# Altium schematic sheet Э3("All Documents",Physical)
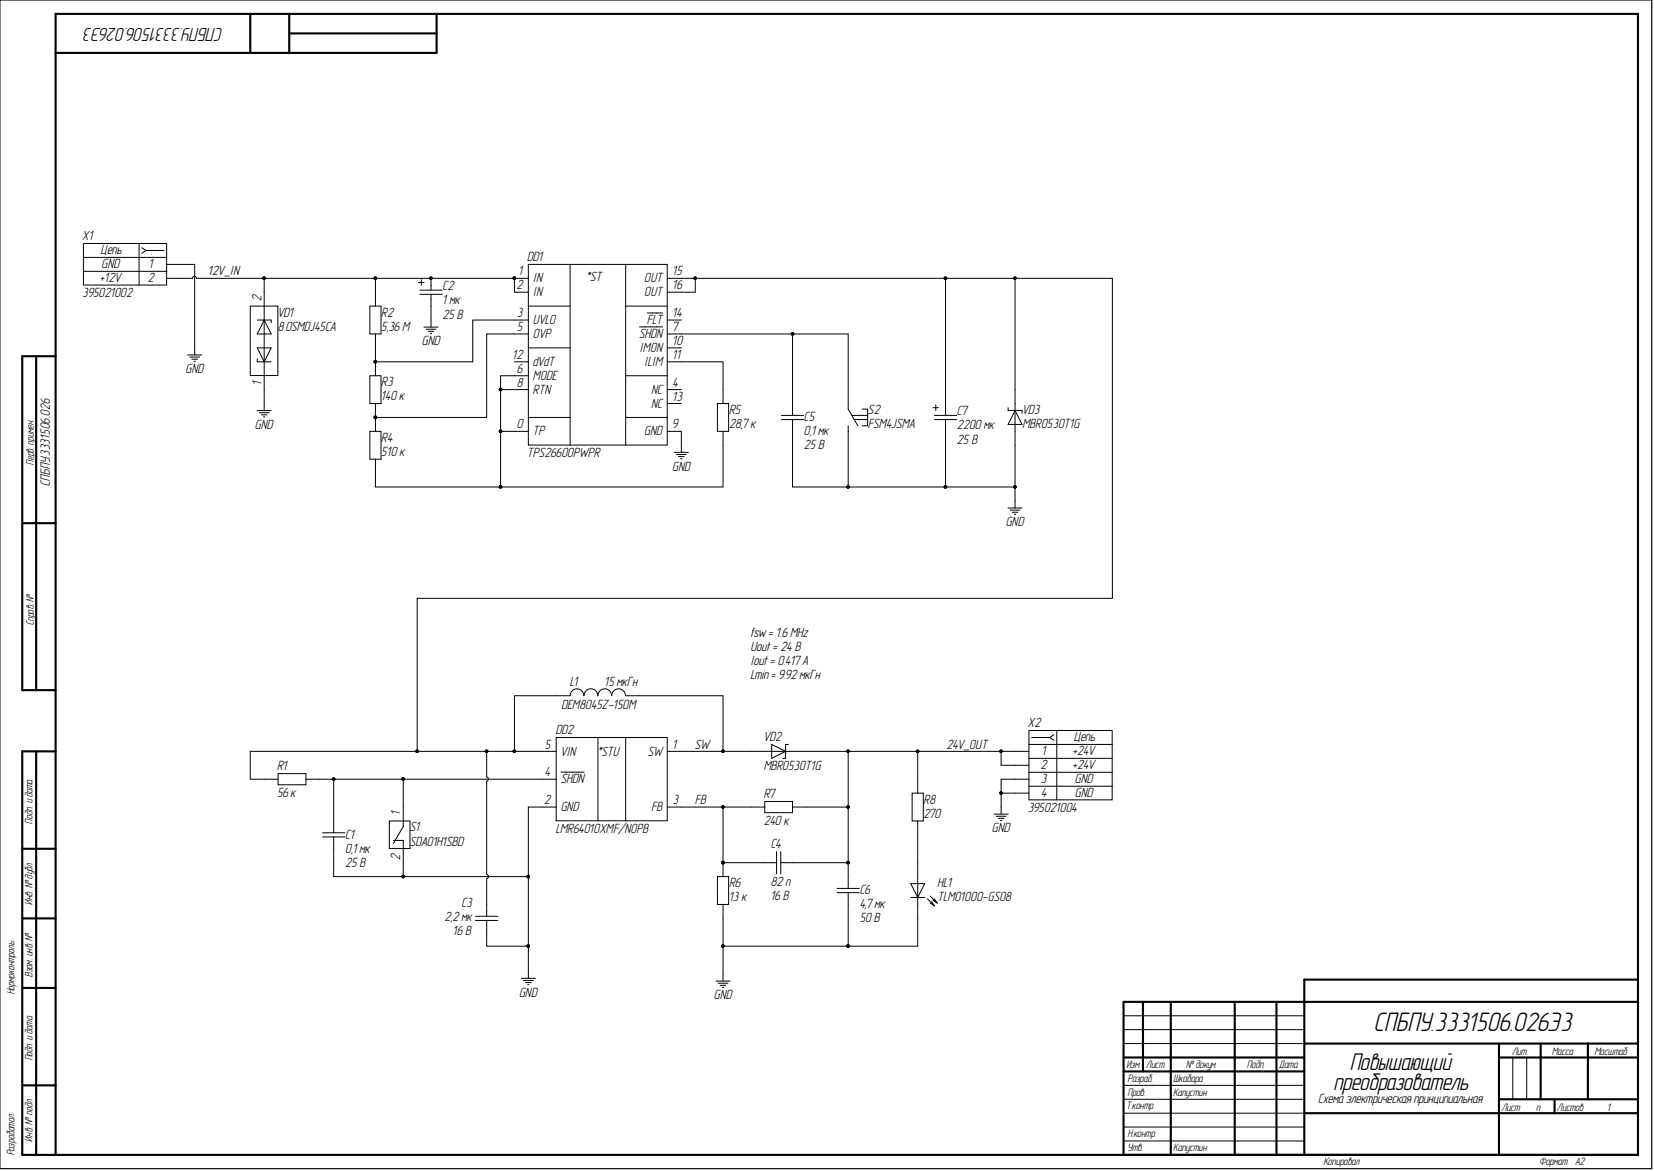
[diagram p0: Э3("All Documents",Physical)]

## Parts
C1  0,1 мк  Номинальное напряжение: 25 В  [C0402]
C2  1 мк  Номинальное напряжение: 25 В  [C1206POLAR]
C3  2,2 мк  Номинальное напряжение: 16 В  [C0603]
C4  82 п  Номинальное напряжение: 16 В  [C0603]
C5  0,1 мк  Номинальное напряжение: 25 В  [C0402]
C6  4,7 мк  Номинальное напряжение: 50 В  [C0805]
C7  2200 мк  Номинальное напряжение: 25 В  [CAPAE-1600X1600X2100]
DD1  TPS26600PWPR  [HTSSOP-16]
DD2  LMR64010XMF/NOPB  [SOT23-5]
HL1  TLMO1000-GS08  [HL0603]
L1  DEM8045Z-150M  Номинал: 15 мкГн  [DEM-2-800X800X450]
R1  56 к  [R0603]
R2  5,36 M  [R0603]
R3  140 к  [R0603]
R4  510 к  [R0603]
R5  28,7 к  [R0603]
R6  13 к  [R0603]
R7  240 к  [R0603]
R8  270  [R0603]
S1  SDA01H1SBD  [DIP-SWITCH-01-452X930X545]
S2  FSM4JSMA  [FSM4JSMA]
VD1  8.0SMDJ45CA  [DO-214AB]
VD2  MBR0530T1G  [SOD123]
VD3  MBR0530T1G  [SOD123]
X1  395021002  Contact_2: +12V  Contact_1: GND  [395021002]
X2  395021004  Contact_4: GND  Contact_2: +24V  [395021004]

## Nets
GND: C1.2 C2.2 C3.2 C5.2 C6.2 C7.2 DD1.9(GND) DD2.2(GND) HL1.1 R6.1 S1.2 S2.2 VD1.1 VD3.2 X1.1 X2.3 X2.4
12V_IN: C2.1 DD1.1(IN) DD1.2(IN) R2.2 VD1.2 X1.2
24V_OUT: C4.1 C6.1 R7.2 R8.2 VD2.1 X2.1 X2.2
FB: C4.2 DD2.3(FB) R6.2 R7.1
SW: DD2.1(SW) L1.2 VD2.2
NetC1_1: C1.1 DD2.4(SHDN) R1.1 S1.1
NetC3_1: C3.1 C7.1 DD1.15(OUT) DD1.16(OUT) DD2.5(VIN) L1.1 R1.2 VD3.1
NetC5_1: C5.1 DD1.7(SHDN) S2.1
NetDD1_0: DD1.0(TP) DD1.6(MODE) DD1.8(RTN) R4.1 R5.1
NetDD1_3: DD1.3(UVLO) R2.1 R3.2
NetDD1_4: DD1.4(NC)
NetDD1_5: DD1.5(OVP) R3.1 R4.2
NetDD1_10: DD1.10(IMON)
NetDD1_11: DD1.11(ILIM) R5.2
NetDD1_12: DD1.12(dVdT)
NetDD1_13: DD1.13(NC)
NetDD1_14: DD1.14(FLT)
NetHL1_2: HL1.2 R8.1

## Library data of the parts
C1 C5 = c0021: =Описание; Фамилия: Паньков; ТКЕ: X5R; Рабочие температуры: от –55 °С до +85 °С; Производитель: YAGEO Corp.; Отклонение: ±10 %; Описание: Конденсатор чип 0402 0,1 мкФ 25 В ±10 %; Наименование: CC0402KRX5R8BB104; Корпус: 0402; Database Table Name: Конденсаторы$; Номинал: 0,1 мк; Напряжение: 25 В; ID: c0021; HelpURL: https://www.yageo.com/upload/media/product/productsearch/datasheet/mlcc/UPY-GPHC_X5R_4V-to-50V_26.pdf; Description: Конденсатор чип 0402 0,1 мкФ 25 В ±10 %; Component Kind: Standard; Library Name: Database_Students.DbLib
C2 = c0107: =Описание; Фамилия: Ларионов; ТКЕ: X7R; Рабочие температуры: от –55 °С до +125 °С; Производитель: Vishay Intertechnology, Inc.; Отклонение: ±10 %; Описание: Конденсатор чип 1206 1 мкФ 25 В ±10 % полярный; Наименование: 293D105X9025A2TE3; Корпус: 1206; Database Table Name: Конденсаторы$; Номинал: 1 мк; Напряжение: 25 В; ID: c0107; HelpURL: https://www.vishay.com/capacitors/list/product-40002/; Description: Конденсатор чип 1206 1 мкФ 25 В ±10 % полярный; Component Kind: Standard; Library Name: Database_Students.DbLib
C3 = c0061: =Описание; Фамилия: Паньков; ТКЕ: X5R; Рабочие температуры: от –55 °С до +85 °С; Производитель: YAGEO Corp.; Отклонение: ±10 %; Описание: Конденсатор чип 0603 2,2 мкФ 16 В ±10 %; Наименование: CC0603KRX5R7BB225; Корпус: 0603; Database Table Name: Конденсаторы$; Номинал: 2,2 мк; Напряжение: 16 В; ID: c0061; HelpURL: https://www.yageo.com/upload/media/product/productsearch/datasheet/mlcc/UPY-GPHC_X5R_4V-to-50V_26.pdf; Description: Конденсатор чип 0603 2,2 мкФ 16 В ±10 %; Component Kind: Standard; Library Name: Database_Students.DbLib
C4 = c0011: =Описание; Фамилия: Ларионов; ТКЕ: C0G; Рабочие температуры: от –55 °С до +125 °С; Производитель: YAGEO Corp.; Отклонение: ±5 %; Описание: Конденсатор чип 0603 82 пФ 16 В ±5 %; Наименование: CC0603JRNPO7BN820; Корпус: 0603; Database Table Name: Конденсаторы$; Номинал: 82 п; Напряжение: 16 В; ID: c0011; HelpURL: https://www.yageo.com/upload/media/product/productsearch/datasheet/mlcc/UPY-GP_NP0_16V-to-50V_18.pdf; Description: Конденсатор чип 0603 82 пФ 16 В ±5 %; Component Kind: Standard; Library Name: Database_Students.DbLib
C6 = c0073: =Описание; Фамилия: Паньков; ТКЕ: X5R; Рабочие температуры: от –55 °С до +85 °С; Производитель: YAGEO Corp.; Отклонение: ±10 %; Описание: Конденсатор чип 0805 4,7 мкФ 50 В ±10 %; Наименование: CC0805KRX5R9BB475; Корпус: 0603; Database Table Name: Конденсаторы$; Номинал: 4,7 мк; Напряжение: 50 В; ID: c0073; HelpURL: https://www.yageo.com/upload/media/product/productsearch/datasheet/mlcc/UPY-GPHC_X5R_4V-to-50V_26.pdf; Description: Конденсатор чип 0805 4,7 мкФ 50 В ±10 %; Component Kind: Standard; Library Name: Database_Students.DbLib
C7 = c0109: =Описание; Фамилия: Шкабара; Рабочие температуры: от –55 °С до +125 °С; Производитель: Vishay Intertechnology, Inc.; Отклонение: ±20 %; Описание: Конденсатор электролитический 2200 мкФ 25 В ±20 %; Наименование: MAL214699607E3; Корпус: CAPAE (16 x 16 x 21); Database Table Name: Конденсаторы$; Температурный коэффициент емкости: -; Номинал: 2200 мк; Напряжение: 25 В; ID: c0109; HelpURL: https://www.vishay.com/capacitors/list/product-28403/; Description: Конденсатор электролитический 2200 мкФ 25 В ±20 %; Component Kind: Standard; Library Name: Database_Students.DbLib
DD1 = dd0001: =Описание; Фамилия: Ларионов; Ток: от 0,1 А до 2,23 А; Рабочие температуры: от -40 °С до +125 °С; Производитель: Texas Instruments; Описание: Защитная микросхема eFuse 60 В и 2 А; Напряжение: от 4,2 В до 60 В; Максимальное напряжение: 62 В; Database Table Name: Защитные микросхемы$; Наименование: TPS26600PWPR; Корпус: HTSSOP-16; ID: dd0001; HelpURL: https://www.ti.com/product/TPS2660; Description: Защитная микросхема eFuse 60 В и 2 А; Component Kind: Standard; Library Name: Database_Students.DbLib
DD2 = dd0008: =Описание; Частота переключения: 1,6 МГц; Фамилия: Шкабара; Рабочие температуры: от -40 °С до +125 °С; Производитель: Texas Instruments; Описание: Повышающий преобразователь напряжения 40 В и 1 А; Коммутируемый ток: от 0 А до 1 А; Выходное напряжение: от 3 В до 40 В; Входное напряжение: от 2,7 В до 14 В; Database Table Name: Преобразователи напряжения$; Наименование: LMR64010XMF/NOPB; Корпус: SOT23-5; ID: dd0008; HelpURL: https://www.ti.com/product/LMR64010; Description: Повышающий преобразователь напряжения 40 В и 1 А; Component Kind: Standard; Library Name: Database_Students.DbLib
HL1 = hl0002: =Описание; Цвет: Soft orange; Фамилия: Ларионов; Рассеиваемая мощность: 40 мВт; Рабочие температуры: от –40 °С до +100 °С; Производитель: Vishay Intertechnology, Inc.; Описание: Светодиод чип 0603 светло-оранжевый; Номинальный прямой ток: 15 мА; Номинальное падение напряжения: 1,8 В; Номинальная сила света: 7,5 мкд; Номинальная длина волны: 605 нм; Корпус: 0603; Database Table Name: Светодиоды$; Наименование: TLMO1000-GS08; ID: hl0002; HelpURL: https://www.vishay.com/leds/list/product-83172/; Description: Светодиод чип 0603 светло-оранжевый; Component Kind: Standard; Library Name: Database_Students.DbLib
L1 = l0005: =Описание; Фамилия: Шкабара; Ток насыщения: 3,3 А; Резонансная частота: 24 МГц; Рабочие температуры: от –40 °С до +125 °С; Рабочее напряжение: не указано; Производитель: Murata Manufacturing Co., Ltd.; Отклонение: ±20 %; Описание: Катушка индуктивности 15 мкГн ±20 %; Номинальный ток (при нагреве на 20 °С/40 °С): 3,5 А; Номинальное активное сопротивление: 44 мОм; Максимальное активное сопротивление: 52,8 мОм; Корпус: 8,0 x 8,0 x 4,5; ID: l0006; Database Table Name: Катушки индуктивности$; Наименование: DEM8045Z-150M; Индуктивность: 15 мкГн; HelpURL: https://www.murata.com/en-us/products/productdetail?partno=DEM8045Z-150M%23; Description: Катушка индуктивности 15 мкГн ±20 %; Component Kind: Standard; Library Name: Database_Students.DbLib
R1 = r0085: =Описание; Фамилия: Паньков; Рабочие температуры: от –55 °С до +155 °С; Производитель: YAGEO Corp.; Отклонение: ±1 %; Описание: Резистор чип 0603 56 кОм ±1 % 0,1 Вт; Номинальный ток: 1 А; Номинальное напряжение: 75 В; Наименование: RC0603FR-0756KL; Корпус: 0603; Database Table Name: Резисторы$; Номинал: 56 к; ID: r0085; HelpURL: https://www.yageo.com/upload/media/product/productsearch/datasheet/rchip/PYu-RC_Group_51_RoHS_L_11.pdf; Description: Резистор чип 0603 56 кОм ±1 % 0,1 Вт; Component Kind: Standard; Library Name: Database_Students.DbLib
R2 = r0128: =Описание; Фамилия: Шкабара; Рабочие температуры: от –55 °С до +155 °С; Производитель: YAGEO Corp.; Отклонение: ±1 %; Описание: Резистор чип 0603 5,36 МОм ±1 % 0,1 Вт; Номинальный ток: 1 А; Номинальное напряжение: 75 В; Наименование: RC0603FR-075M36L; Корпус: 0603; Database Table Name: Резисторы$; Номинал: 5,36 M; ID: r0128; HelpURL: https://www.yageo.com/upload/media/product/productsearch/datasheet/rchip/PYu-RC_Group_51_RoHS_L_11.pdf; Description: Резистор чип 0603 5,36 МОм ±1 % 0,1 Вт; Component Kind: Standard; Library Name: Database_Students.DbLib
R3 = r0099: =Описание; Фамилия: Шкабара; Рабочие температуры: от –55 °С до +155 °С; Производитель: YAGEO Corp.; Отклонение: ±1 %; Описание: Резистор чип 0603 140 кОм ±1 % 0,1 Вт; Номинальный ток: 1 А; Номинальное напряжение: 75 В; Наименование: RC0603FR-07140KL; Корпус: 0603; Database Table Name: Резисторы$; Номинал: 140 к; ID: r0099; HelpURL: https://www.yageo.com/upload/media/product/productsearch/datasheet/rchip/PYu-RC_Group_51_RoHS_L_11.pdf; Description: Резистор чип 0603 140 кОм ±1 % 0,1 Вт; Component Kind: Standard; Library Name: Database_Students.DbLib
R4 = r0116: =Описание; Фамилия: Паньков; Рабочие температуры: от –55 °С до +155 °С; Производитель: YAGEO Corp.; Отклонение: ±1 %; Описание: Резистор чип 0603 510 кОм ±1 % 0,1 Вт; Номинальный ток: 1 А; Номинальное напряжение: 75 В; Наименование: RC0603FR-07510KL; Корпус: 0603; Database Table Name: Резисторы$; Номинал: 510 к; ID: r0116; HelpURL: https://www.yageo.com/upload/media/product/productsearch/datasheet/rchip/PYu-RC_Group_51_RoHS_L_11.pdf; Description: Резистор чип 0603 510 кОм ±1 % 0,1 Вт; Component Kind: Standard; Library Name: Database_Students.DbLib
R5 = r0075: =Описание; Фамилия: Шкабара; Рабочие температуры: от –55 °С до +155 °С; Производитель: YAGEO Corp.; Отклонение: ±1 %; Описание: Резистор чип 0603 28,7 кОм ±1 % 0,1 Вт; Номинальный ток: 1 А; Номинальное напряжение: 75 В; Наименование: RC0603FR-0728K7L; Корпус: 0603; Database Table Name: Резисторы$; Номинал: 28,7 к; ID: r0075; HelpURL: https://www.yageo.com/upload/media/product/productsearch/datasheet/rchip/PYu-RC_Group_51_RoHS_L_11.pdf; Description: Резистор чип 0603 28,7 кОм ±1 % 0,1 Вт; Component Kind: Standard; Library Name: Database_Students.DbLib
R6 = r0065: =Описание; Фамилия: Жернаков; Рабочие температуры: от –55 °С до +155 °С; Производитель: YAGEO Corp.; Отклонение: ±1 %; Описание: Резистор чип 0603 13 кОм ±1 % 0,1 Вт; Номинальный ток: 1 А; Номинальное напряжение: 75 В; Наименование: RC0603FR-0713KL; Корпус: 0603; Database Table Name: Резисторы$; Номинал: 13 к; ID: r0065; HelpURL: https://www.yageo.com/upload/media/product/productsearch/datasheet/rchip/PYu-RC_Group_51_RoHS_L_11.pdf; Description: Резистор чип 0603 13 кОм ±1 % 0,1 Вт; Component Kind: Standard; Library Name: Database_Students.DbLib
R7 = r0106: =Описание; Фамилия: Паньков; Рабочие температуры: от –55 °С до +155 °С; Производитель: YAGEO Corp.; Отклонение: ±1 %; Описание: Резистор чип 0603 240 кОм ±1 % 0,1 Вт; Номинальный ток: 1 А; Номинальное напряжение: 75 В; Наименование: RC0603FR-07240KL; Корпус: 0603; Database Table Name: Резисторы$; Номинал: 240 к; ID: r0106; HelpURL: https://www.yageo.com/upload/media/product/productsearch/datasheet/rchip/PYu-RC_Group_51_RoHS_L_11.pdf; Description: Резистор чип 0603 240 кОм ±1 % 0,1 Вт; Component Kind: Standard; Library Name: Database_Students.DbLib
R8 = r0017: =Описание; Фамилия: Паньков; Рабочие температуры: от –55 °С до +155 °С; Производитель: YAGEO Corp.; Отклонение: ±1 %; Описание: Резистор чип 0603 270 Ом ±1 % 0,1 Вт; Номинальный ток: 1 А; Номинальное напряжение: 75 В; Наименование: RC0603FR-07270RL; Корпус: 0603; Database Table Name: Резисторы$; Номинал: 270; ID: r0017; HelpURL: https://www.yageo.com/upload/media/product/productsearch/datasheet/rchip/PYu-RC_Group_51_RoHS_L_11.pdf; Description: Резистор чип 0603 270 Ом ±1 % 0,1 Вт; Component Kind: Standard; Library Name: Database_Students.DbLib
S1 = sw0002: =Описание; Фамилия: Паньков; Ток нагрузки: 100 мА @ 5 В; Сопротивление контакта: 50 мОм; Рабочие температуры: от –40 °С до +85 °С; Промывка: Разрешена; Производитель: C&K; Описание: 2-х позиционный движковый переключатель; Корпус: 4,52х9,30х5,45; Количество контактов: 2; Database Table Name: Переключатели$; Наименование: SDA01H1SBD; ID: sw0002; HelpURL: https://www.ckswitches.com/products/switches/product-details/DIP/SDA/SDA01H1SBD/; Description: 2-х позиционный движковый переключатель; Component Kind: Standard; Library Name: Database_Students.DbLib
S2 = sw0001: =Описание; Фамилия: Паньков; Ток нагрузки: 50 мА @ 24 В; Сопротивление контакта: 100 мОм; Рабочие температуры: от –35 °С до +85 °С; Промывка: Разрешена; Производитель: TE Connectivity; Описание: Тактовая кнопка поверхностного монтажа; Корпус: 6,00x6,00x4,98; Количество контактов: 4; Database Table Name: Переключатели$; Наименование: FSM4JSMA; ID: sw0001; HelpURL: https://www.te.com/usa-en/product-4-1437565-1.html; Description: Тактовая кнопка поверхностного монтажа; Component Kind: Standard; Library Name: Database_Students.DbLib
VD1 = vd0005: =Описание; Фамилия: Шкабара; Производитель: Littelfuse, Inc.; Описание: Двунаправленная диодная сборка для подавления помех, 45 В; Database Table Name: Диодные сборки$; Наименование: 8.0SMDJ45CA; Корпус: DO-214AB; ID: vd0005; HelpURL: https://www.littelfuse.com/products/tvs-diodes/surface-mount/8.0smdj.aspx; Description: Двунаправленная диодная сборка для подавления помех, 45 В; Component Kind: Standard; Library Name: Database_Students.DbLib
VD2 VD3 = vd0001: =Описание; Фамилия: Шкабара; Рабочие температуры: от –65 °С до +125 °С; Производитель: ON Semiconductor; Пиковое прямое напряжение: 0,43 В; Описание: Диод Шоттки 30 В 0.5 А; Макс допустимый средний прямой ток: 0.5 А; Импульсное обратное напряжение: 30 В; Database Table Name: Диоды$; Наименование: MBR0530T1G; Корпус: SOD123; ID: vd0001; HelpURL: https://www.onsemi.com/products/discretes-drivers/diodes-rectifiers/schottky-diodes-schottky-rectifiers/mbr0530t1g; Description: Диод Шоттки 30 В 0.5 А; Component Kind: Standard; Library Name: Database_Students.DbLib
X1 = x0008: =Описание; Фамилия: Шкабара; Производитель: Molex Incorporated; Описание: Eurostyle terminal block 2 pin 3,5 мм гнездо угловой; Database Table Name: Разъемы$; Наименование: 395021002; ID: x0008; HelpURL: https://www.molex.com/molex/products/part-detail/terminal_blocks/0395021002; Description: Eurostyle terminal block 2 pin 3,5 мм гнездо угловой; Component Kind: Standard; Library Name: Database_Students.DbLib
X2 = x0009: =Описание; Фамилия: Шкабара; Производитель: Molex Incorporated; Описание: Eurostyle terminal block 4 pin 3,5 мм гнездо угловой; Database Table Name: Разъемы$; Наименование: 395021004; ID: x0009; HelpURL: https://www.molex.com/molex/products/part-detail/terminal_blocks/0395021004; Description: Eurostyle terminal block 4 pin 3,5 мм гнездо угловой; Contact_3: GND; Contact_1: +24V; Component Kind: Standard; Library Name: Database_Students.DbLib
